# Altium schematic sheet Cover Sheet.SchDoc("Cover Sheet")
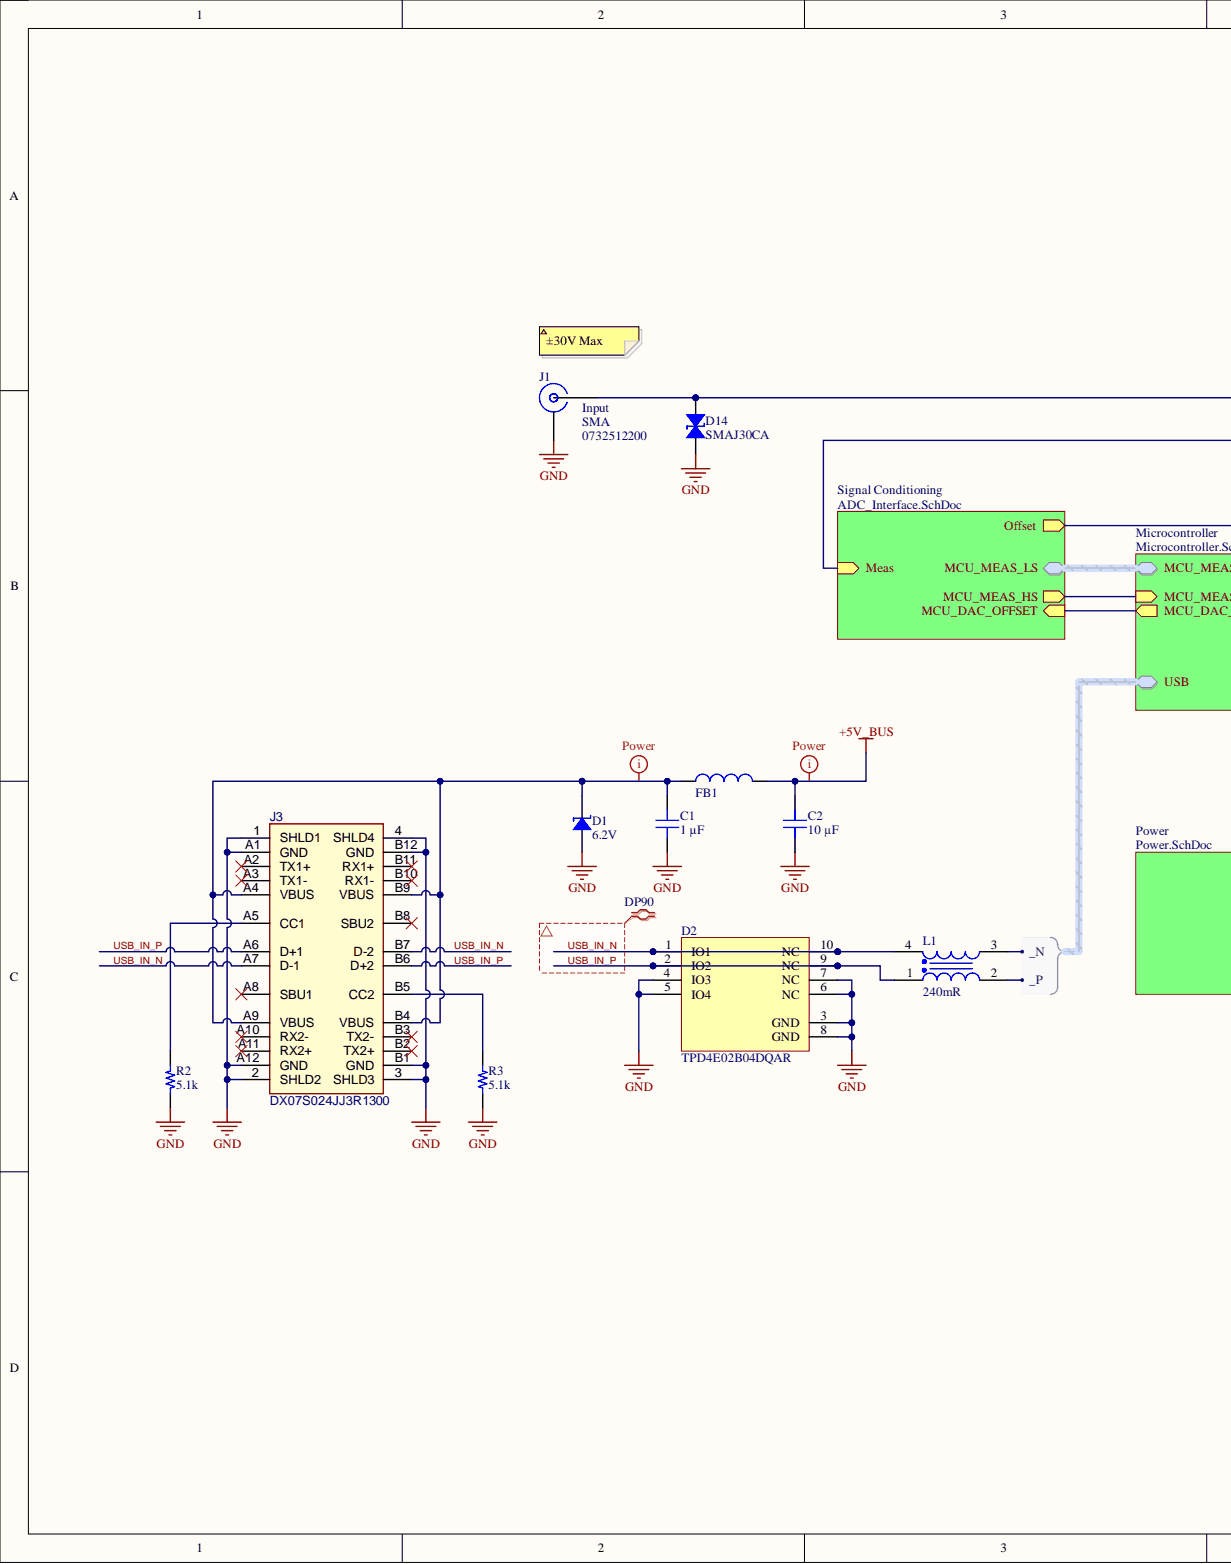
[diagram p0: Cover Sheet.SchDoc, left]
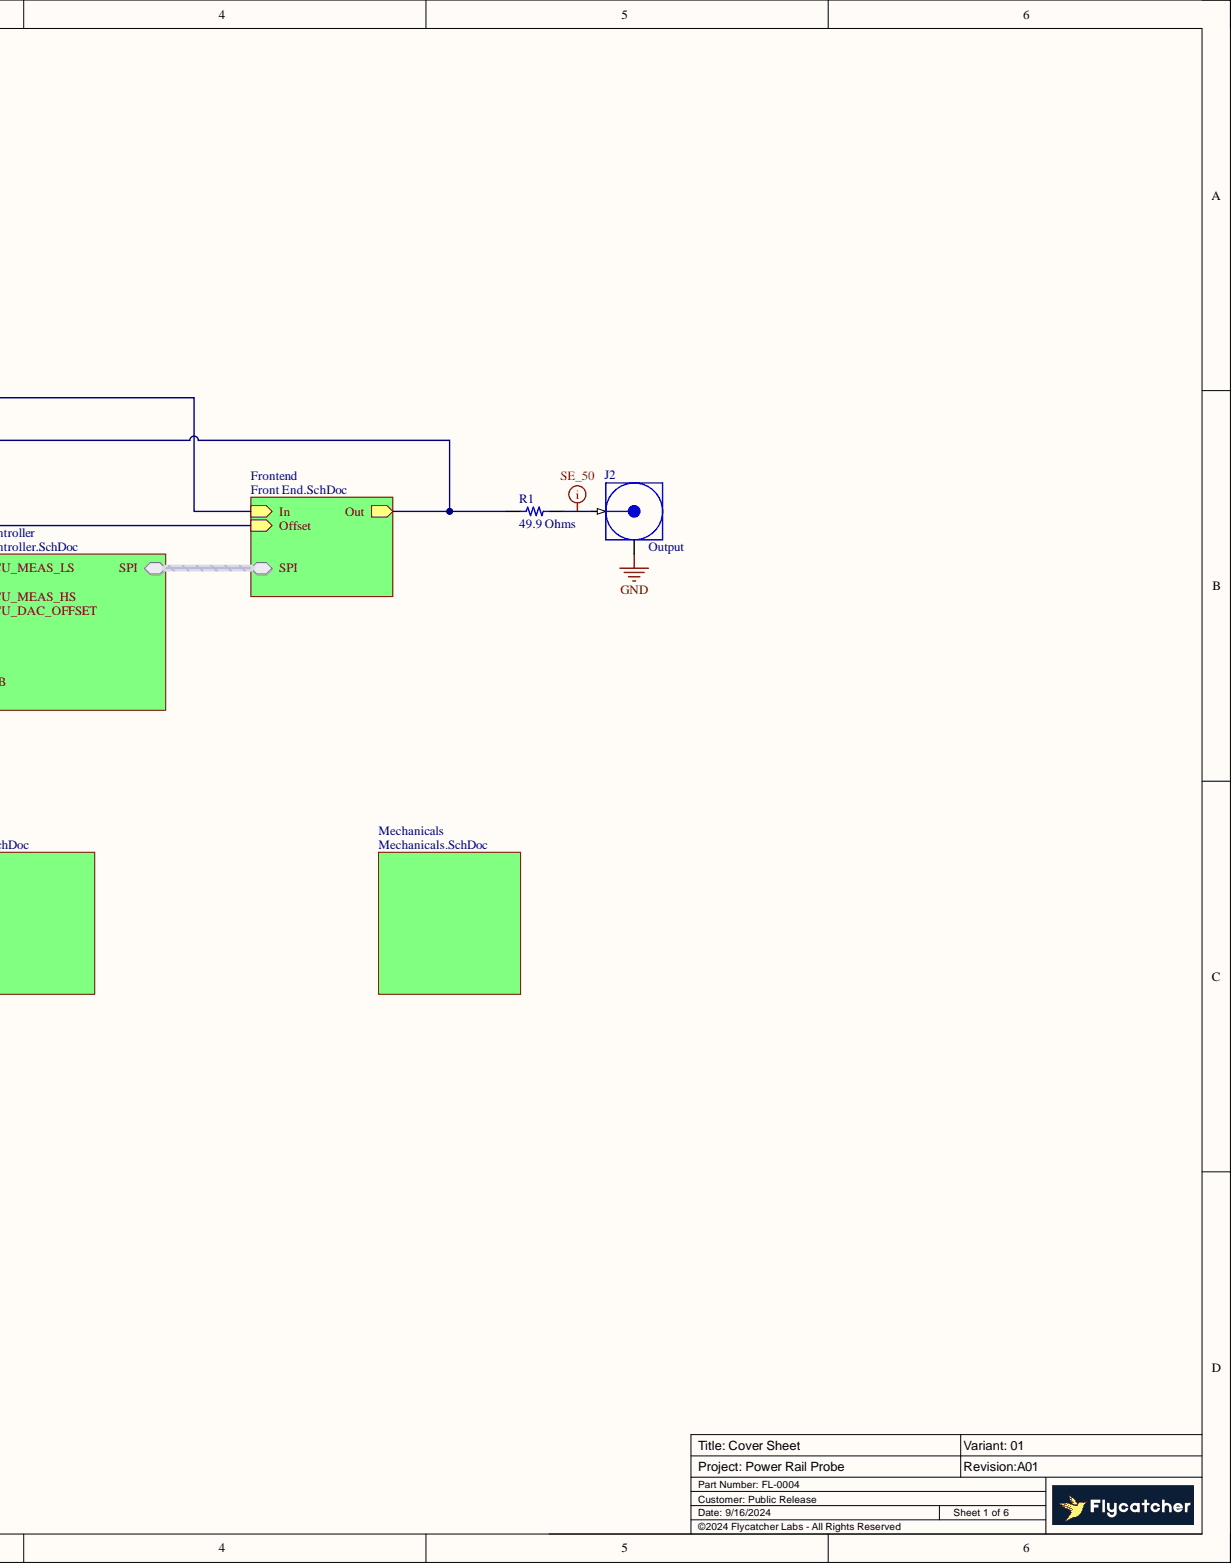
[diagram p1: Cover Sheet.SchDoc, right]

## Parts
C1  1 µF  [CAP 0402_1005]
C2  10 µF  [CAP 0805_2012]
D1  Voltage - Breakdown (Min): 6.2V  [SOD323]  (hidden comment: D5V0S1U2WS-7)
D2  TPD4E02B04DQAR  [FP-DQA0010A-MFG]
D14  SMAJ30CA  [DO214AC SMA]
FB1  74279226101  [WE-MPSB_1812_L4.5W3.2H2.3]  (value not drawn)
J1  Input  Connector Style: SMA  [MOLEX SD-73251-220 (NICKEL, 2.79MM FEET)]
J2  Output  [TE - 5227161-3]
J3  DX07S024JJ3R1300  [JAE-DX07S024JJ3_V]
L1  value 240mR  DC Resistance (DCR): 240mR  [WE-CNSW HF_0805]  (hidden comment: 744233670)
R1  49.9 Ohms  [RES 0805_2012]
R2  5.1k  [RES 0402_1005]
R3  5.1k  [RES 0402_1005]

## Nets
+5V_BUS: C2.2 FB1.1
GND: C1.1 C2.1 D1.1 D2.3(GND) D2.4(IO3) D2.5(IO4) D2.6(NC) D2.7(NC) D2.8(GND) D14.1 J1.G J2.G J3.1(SHLD1) J3.2(SHLD2) J3.3(SHLD3) J3.4(SHLD4) J3.A1(GND) J3.A12(GND) J3.B1(GND) J3.B12(GND) R2.1 R3.1
USB_IN_N: D2.1(IO1) D2.10(NC) J3.A7(D-1) J3.B7(D-2) L1.4
USB_IN_P: D2.2(IO2) D2.9(NC) J3.A6(D+1) J3.B6(D+2) L1.1
NetC1_2: C1.2 D1.2 FB1.2 J3.A4(VBUS) J3.A9(VBUS) J3.B4(VBUS) J3.B9(VBUS)
NetD14_2: D14.2 J1.S
NetJ2_S: J2.S R1.2
NetJ3_A2: J3.A2(TX1+)
NetJ3_A3: J3.A3(TX1-)
NetJ3_A5: J3.A5(CC1) R2.2
NetJ3_A8: J3.A8(SBU1)
NetJ3_A10: J3.A10(RX2-)
NetJ3_A11: J3.A11(RX2+)
NetJ3_B2: J3.B2(TX2+)
NetJ3_B3: J3.B3(TX2-)
NetJ3_B5: J3.B5(CC2) R3.2
NetJ3_B8: J3.B8(SBU2)
NetJ3_B10: J3.B10(RX1-)
NetJ3_B11: J3.B11(RX1+)
NetL1_2: L1.2
NetL1_3: L1.3
NetR1_1: R1.1
N00001:
N00002:
N00003:
N00004:
N00005:
N00006:
N00007:
N00008:
N00009:

## Library data of the parts
C1 = CL05A105KO5NNNC: CAP CER 1UF 16V X5R 0402; manufacturer: Samsung Electro-Mechanics; Database Table Name: Capacitors - Ceramic - 0402; Tolerance: ±10%; Applications: General Purpose; Capacitance: 1 µF; Component Kind: Standard; componentlink1description: Datasheet; componentlink1url: http://www.samsungsem.com/kr/support/product-search/mlcc/CL05A105KO5NNNC.jsp; componentlink2description: DigiKey Link; componentlink2url: https://www.digikey.com/en/products/detail/samsung-electro-mechanics/CL05A105KO5NNNC/3886725; Device Package: 0402 (1005 Metric); lastupdated: 2/9/2024 8:04:35 PM; Library Name: Celestial Altium Library - altium_library.DbLib; Lifecycle Status: Active; Minimum Order: 1; Mounting Type: Surface Mount, MLCC; Operating Temperature: -55°C ~ 85°C; Package / Case: 0402 (1005 Metric); packaging: TapeAndReel; Part Number: CL05A105KO5NNNC; Part Status: Active; partid: 3120; price: 0.01; series: CL; Size / Dimension: 0.039" L x 0.020" W (1.00mm x 0.50mm); Supplier 1: DigiKey; Supplier Part Number 1: 1276-1067-1-ND; Temperature Coefficient: X5R; Thickness (Max): 0.022" (0.55mm); Value: 1 µF; Voltage - Rated: 16V
C2 = GCM21BC71E106KE36L: CAP CER 10UF 25V X7S 0805; manufacturer: Murata Electronics; Database Table Name: Capacitors - Ceramic - 0805; Tolerance: ±10%; Applications: Automotive; Capacitance: 10 µF; Component Kind: Standard; componentlink1description: Datasheet; componentlink1url: https://search.murata.co.jp/Ceramy/image/img/A01X/G101/ENG/GCM21BC71E106KE36-01A.pdf; componentlink2description: DigiKey Link; componentlink2url: https://www.digikey.com/en/products/detail/murata-electronics/GCM21BC71E106KE36L/16821116; Device Package: 0805 (2012 Metric); lastupdated: 2/9/2024 8:14:44 PM; Library Name: Celestial Altium Library - altium_library.DbLib; Lifecycle Status: Active; Minimum Order: 1; Mounting Type: Surface Mount, MLCC; Operating Temperature: -55°C ~ 125°C; Package / Case: 0805 (2012 Metric); packaging: TapeAndReel; Part Number: GCM21BC71E106KE36L; Part Status: Active; partid: 48794; price: 0.09; Ratings: AEC-Q200; series: GCM; Size / Dimension: 0.079" L x 0.049" W (2.00mm x 1.25mm); Supplier 1: DigiKey; Supplier Part Number 1: 490-GCM21BC71E106KE36LCT-ND; Temperature Coefficient: X7S; Thickness (Max): 0.057" (1.45mm); Value: 10 µF; Voltage - Rated: 25V
D1 = D5V0S1U2WS-7: TVS DIODE 5.5V 16V SOD323; Manufacturer: Diodes Incorporated; Capacitance @ Frequency: 950pF @ 1MHz; Current - Peak Pulse (10/1000µs): 70A (8/20µs); Database Table Name: Diodes - TVS; Power - Peak Pulse: 1000W (1kW); Power Line Protection: No; Type: Zener; Unidirectional Channels: 1; Voltage - Reverse Standoff (Typ): 5.5V (Max); Applications: Automotive; Component Kind: Standard; ComponentLink1Description: Datasheet; ComponentLink1URL: https://www.diodes.com/assets/Datasheets/D5V0S1U2WS.pdf; ComponentLink2Description: DigiKey Link; ComponentLink2URL: http://digikey.com/product-detail/en/diodes-incorporated/D5V0S1U2WS-7/31-D5V0S1U2WS-7CT-ND/11643340; LastUpdated: 8/30/2020 9:07:50 PM; Library Name: Celestial Altium Library - altium_library.DbLib; Lifecycle Status: Active; Minimum Order: 1; Mounting Type: Surface Mount; Package / Case: SC-76, SOD-323; Packaging: CutTape; Part Number: D5V0S1U2WS-7; PartId: 11491; Price: 0.37; Series: Automotive, AEC-Q101; Supplier 1: DigiKey; Supplier Device Package: SOD-323; Supplier Part Number 1: 31-D5V0S1U2WS-7CT-ND; Voltage - Clamping (Max) @ Ipp: 16V
D2 = CMP-004-00031-2: Quad 0.25-pF, ±3.6-V, ±12-kV ESD protection diode for USB 3.0, HDMI 2.0 & High Speed Signals; Breakdown Voltage: 6.4V; Diode Type: ZENER; Direction: Bidirectional; Interface: USB,HDMI; Min Breakdown Voltage: 5.5V; Number of Bidirectional Channels: 4; Operating Supply Voltage: 3.6V; Peak Pulse Current: 5A; Peak Pulse Power: 17W; Power Line Protection: No; Component Kind: Standard; ComponentLink1Description: Datasheet; ComponentLink1URL: https://www.ti.com/lit/ds/symlink/tpd4e02b04.pdf; Height: 550um; Lead Free: Lead Free; Length: 2.5mm; Max Operating Temperature: 125°C; Min Operating Temperature: -40°C; Mounting Technology: Surface Mount; Number of Channels: 4; Packaging: Cut Tape; Pins: 10; Reach SVHC Compliant: No; Reverse Standoff Voltage: 3.6V; RoHS Compliant: Yes; Series: -; Thickness: 500um; Width: 1mm; Library Name: Flycatcher Labs
D14 = SMAJ30CA: TVS DIODE 30VWM 48.4VC DO214AC; Manufacturer: Littelfuse Inc.; Bidirectional Channels: 1; Current - Peak Pulse (10/1000µs): 8.3A; Database Table Name: Diodes - TVS; Power - Peak Pulse: 400W; Power Line Protection: No; Type: Zener; Voltage - Breakdown (Min): 33.3V; Voltage - Clamping (Max) @ Ipp: 48.4V; Voltage - Reverse Standoff (Typ): 30V; Applications: General Purpose; Component Kind: Standard; ComponentLink1Description: Datasheet; ComponentLink1URL: https://www.littelfuse.com/~/media/electronics/datasheets/tvs_diodes/littelfuse_tvs_diode_smaj_datasheet.pdf.pdf; ComponentLink2Description: DigiKey Link; ComponentLink2URL: https://www.digikey.com/en/products/detail/littelfuse-inc/SMAJ30CA/762295; LastUpdated: 6/3/2024 8:21:17 PM; Library Name: Celestial Altium Library - altium_library.DbLib; Lifecycle Status: Active; Minimum Order: 1; Mounting Type: Surface Mount; Operating Temperature: -65°C ~ 150°C (TJ); Package / Case: DO-214AC, SMA; Packaging: TapeAndReel; Part Number: SMAJ30CA; Part Status: Active; PartId: 7378; Price: 0.08; Series: SMAJ; Supplier 1: DigiKey; Supplier Device Package: DO-214AC (SMA); Supplier Part Number 1: SMAJ30CALFCT-ND
FB1 = CMP-000000-2: Ferrite Beads Multi-Layer Power 100Ohm 25% 100MHz 8A 0.006Ohm DCR 1812 Automotive T/R; Impedance: 100R; Number of Lines: 1; Case Code (Imperial): 1812; Case Code (Metric): 4532; Case/Package: 1812; Component Kind: Standard; ComponentLink1Description: Datasheet; ComponentLink1URL: https://www.we-online.com/components/products/datasheet/74279226101.pdf; Height: 2.3mm; Lead Free: Lead Free; Length: 4.5mm; Max Current Rating: 8A; Max DC Current: 8A; Max Operating Temperature: 125°C; Min Operating Temperature: -55°C; Mounting Technology: Surface Mount; Package Quantity: 2500; Packaging: Cut Tape; RoHS Compliant: Yes; Series: WE-MPSB; Termination: SMD/SMT; Test Frequency: 100MHz; Tolerance: 25%; Weight: 0.000952oz; Width: 3.2mm; Library Name: Flycatcher Labs
J1 = 0732512200: CONN SMA JACK R/A 50 OHM PCB; Manufacturer: Molex; Connector Type: Jack, Female Socket; Contact Termination: Solder; Database Table Name: Connectors - Coaxial RF; Frequency - Max: 18GHz; Impedance: 50Ohm; Number of Ports: 1; Component Kind: Standard; ComponentLink1Description: Datasheet; ComponentLink1URL: https://www.molex.com/pdm_docs/ps/PS-89675-346.pdf; ComponentLink2Description: DigiKey Link; ComponentLink2URL: http://digikey.com/product-detail/en/molex/0732512200/WM9352-ND/3468838; Fastening Type: Threaded; Housing Color: Silver; LastUpdated: 6/29/2022 6:13:17 PM; Library Name: Celestial Altium Library - altium_library.DbLib; Lifecycle Status: Active; Minimum Order: 1; Mounting Feature: Bulkhead - Front Side Nut; Mounting Type: Panel Mount, Through Hole, Right Angle; Packaging: Bulk; Part Number: 0732512200; Part Status: Active; Price: 5.75; Series: 73251; Shield Termination: Solder; Supplier 1: DigiKey; Supplier Part Number 1: WM9352-ND
J2 = CMP-002-00083-2: RF BNC Connector, 1 Contact(s), Female, Panel Mount, Solder Terminal, Locking, Jack; Body Material: Zinc; Body Plating: Nickel; Clock Frequency: 4GHz; Connector Type: BNC,Jack; Dielectric Material: Polymethylpentene; Impedance: 50R; Insulation Resistance: 5GR; Number of Positions: 1; Operating Frequency: 0 to 4 GHz; PCB Mount Retention: With; PCB Mounting Orientation: Right Angle; Polarity: Standard,Normal; Sealable: No (Without); Voltage Rating: 500V; Color: White; Component Kind: Standard; ComponentLink1Description: Datasheet; ComponentLink1URL: https://www.te.com/commerce/DocumentDelivery/DDEController?Action=showdoc&DocId=Customer+Drawing%7F5227161%7FB4%7Fpdf%7FEnglish%7FENG_CD_5227161_B4.pdf%7F5227161-3; Contact Material: Bronze; Contact Plating: Gold; Depth: 14.76mm; Fastening Type: Bayonet; Frequency: 4GHz; Gender: Female; Height: 15.88mm; Housing Color: White; Insulation Material: Polymethylpentene; Length: 14.76mm; Max Operating Temperature: 85°C; Min Operating Temperature: -55°C; Mounting Technology: Panel; Orientation: Right Angle; Package Quantity: 35; Packaging: Rail/Tube; Radiation Hardening: No; Reach SVHC Compliant: No; Series: BNC; Termination: Through Hole; Library Name: Flycatcher Labs
J3 = CMP-002-00065-3: USB-C Connector, 20 V, 1.25 A, -30 to 80 degC, RoHS, Tape and Reel; Gender: Receptacle; Component Kind: Standard; Connector Type: USB-C; Pins: 28; Library Name: Flycatcher Labs
L1 = CMP-006-00022-3: WE-CNSW HF SMT Common Mode Line Filter (High Frequ; Current: 320mA; Frequency: 6.5GHz; Impedance: 67R; Number of Lines: 2; Operating Frequency: 10  1000MHz; Test Frequency: 100MHz; Tolerance: 25%; Voltage Rating: 50V; Case/Package: 0805; Component Kind: Standard; ComponentLink1Description: Datasheet; ComponentLink1URL: https://www.we-online.com/components/products/datasheet/744233670.pdf; Current Rating: 320mA; Depth: 1.2mm; Height: 0.055inch; Lead Free: Lead Free; Length: 0.079inch; Max Current Rating: 320mA; Max DC Current: 320mA; Max Operating Temperature: 105°C; Min Operating Temperature: -40°C; Mounting Technology: Surface Mount; Package Quantity: 1; Packaging: Tape and Reel; Pins: 4; Reach SVHC Compliant: No; RoHS Compliant: Yes; Series: WE-CNSW; Termination: SMD/SMT; Voltage Rating (AC): 50V; Voltage Rating (DC): 50V; Width: 0.85mm; Library Name: Flycatcher Labs
R1 = CRCW080549R9FKEAHP: RES SMD 49.9 OHM 1% 1/2W 0805; Manufacturer: Vishay Dale; Database Table Name: Resistors - Surface Mount - 0805; Power (Watts): 0.5W, 1/2W; Temperature Coefficient: ±100ppm/°C; Component Kind: Standard; ComponentLink1Description: Datasheet; ComponentLink1URL: http://www.vishay.com/docs/20043/crcwhpe3.pdf; ComponentLink2Description: DigiKey Link; ComponentLink2URL: https://www.digikey.com/en/products/detail/vishay-dale/CRCW080549R9FKEAHP/2222324; Composition: Thick Film; Device Package: 0805 (2012 Metric); Features: Automotive AEC-Q200, Pulse Withstanding; Height - Seated (Max): 0.020" (0.50mm); LastUpdated: 2/8/2024 9:02:28 PM; Library Name: Celestial Altium Library - altium_library.DbLib; Lifecycle Status: Active; Minimum Order: 1; Number of Terminations: 2; Operating Temperature: -55°C ~ 155°C; Package / Case: 0805 (2012 Metric); Packaging: TapeAndReel; Part Number: CRCW080549R9FKEAHP; Part Status: Active; PartId: 30317; Price: 0.02; Ratings: AEC-Q200; Resistance: 49.9 Ohms; Series: CRCW-HP; Size / Dimension: 0.079" L x 0.049" W (2.00mm x 1.25mm); Supplier 1: DigiKey; Supplier Device Package: 0805; Supplier Part Number 1: 541-49.9TCT-ND; Tolerance: ±1%; Value: 49.9 Ohms
R2 R3 = CRCW04025K10FKEDC: RES 5.1K OHM 1% 1/16W 0402; Manufacturer: Vishay Dale; Database Table Name: Resistors - Surface Mount - 0402; Power (Watts): 0.063W, 1/16W; Resistance: 5.1 kOhms; Temperature Coefficient: ±100ppm/°C; Value: 5.1 kOhms; Component Kind: Standard; ComponentLink1Description: Datasheet; ComponentLink1URL: http://www.vishay.com/docs/28773/crcwce3.pdf; ComponentLink2Description: DigiKey Link; ComponentLink2URL: https://www.digikey.com/en/products/detail/vishay-dale/CRCW04025K10FKEDC/7928491; Composition: Thick Film; Device Package: 0402 (1005 Metric); Height - Seated (Max): 0.014" (0.35mm); LastUpdated: 2/9/2024 8:29:38 PM; Library Name: Celestial Altium Library - altium_library.DbLib; Lifecycle Status: Active; Minimum Order: 1; Number of Terminations: 2; Operating Temperature: -55°C ~ 155°C; Package / Case: 0402 (1005 Metric); Packaging: CutTape; Part Number: CRCW04025K10FKEDC; Part Status: Active; PartId: 16137; Price: 0.01; Series: CRCW-C; Size / Dimension: 0.039" L x 0.020" W (1.00mm x 0.50mm); Supplier 1: DigiKey; Supplier Device Package: 0402; Supplier Part Number 1: 541-4069-1-ND; Tolerance: ±1%
